# Altium schematic sheet PAGE3-USB_TO_UART.SchDoc(PAGE3-USB_TO_UART)
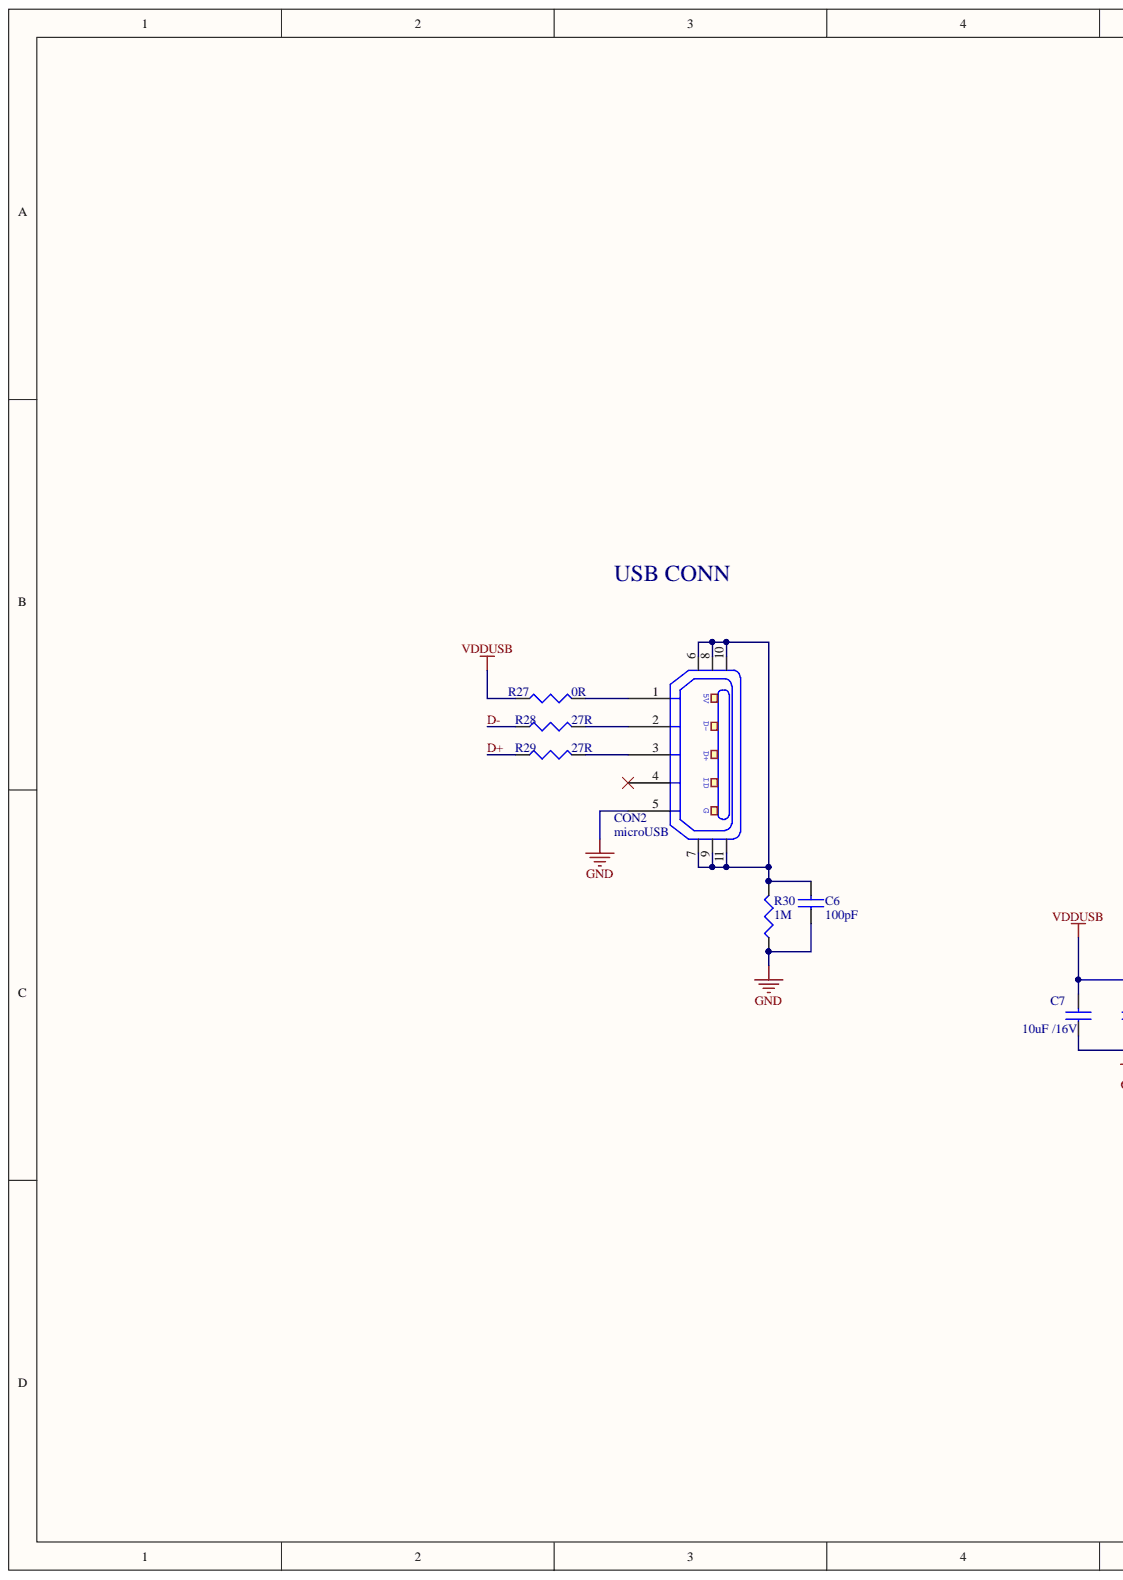
[diagram p0: PAGE3-USB_TO_UART.SchDoc, left]
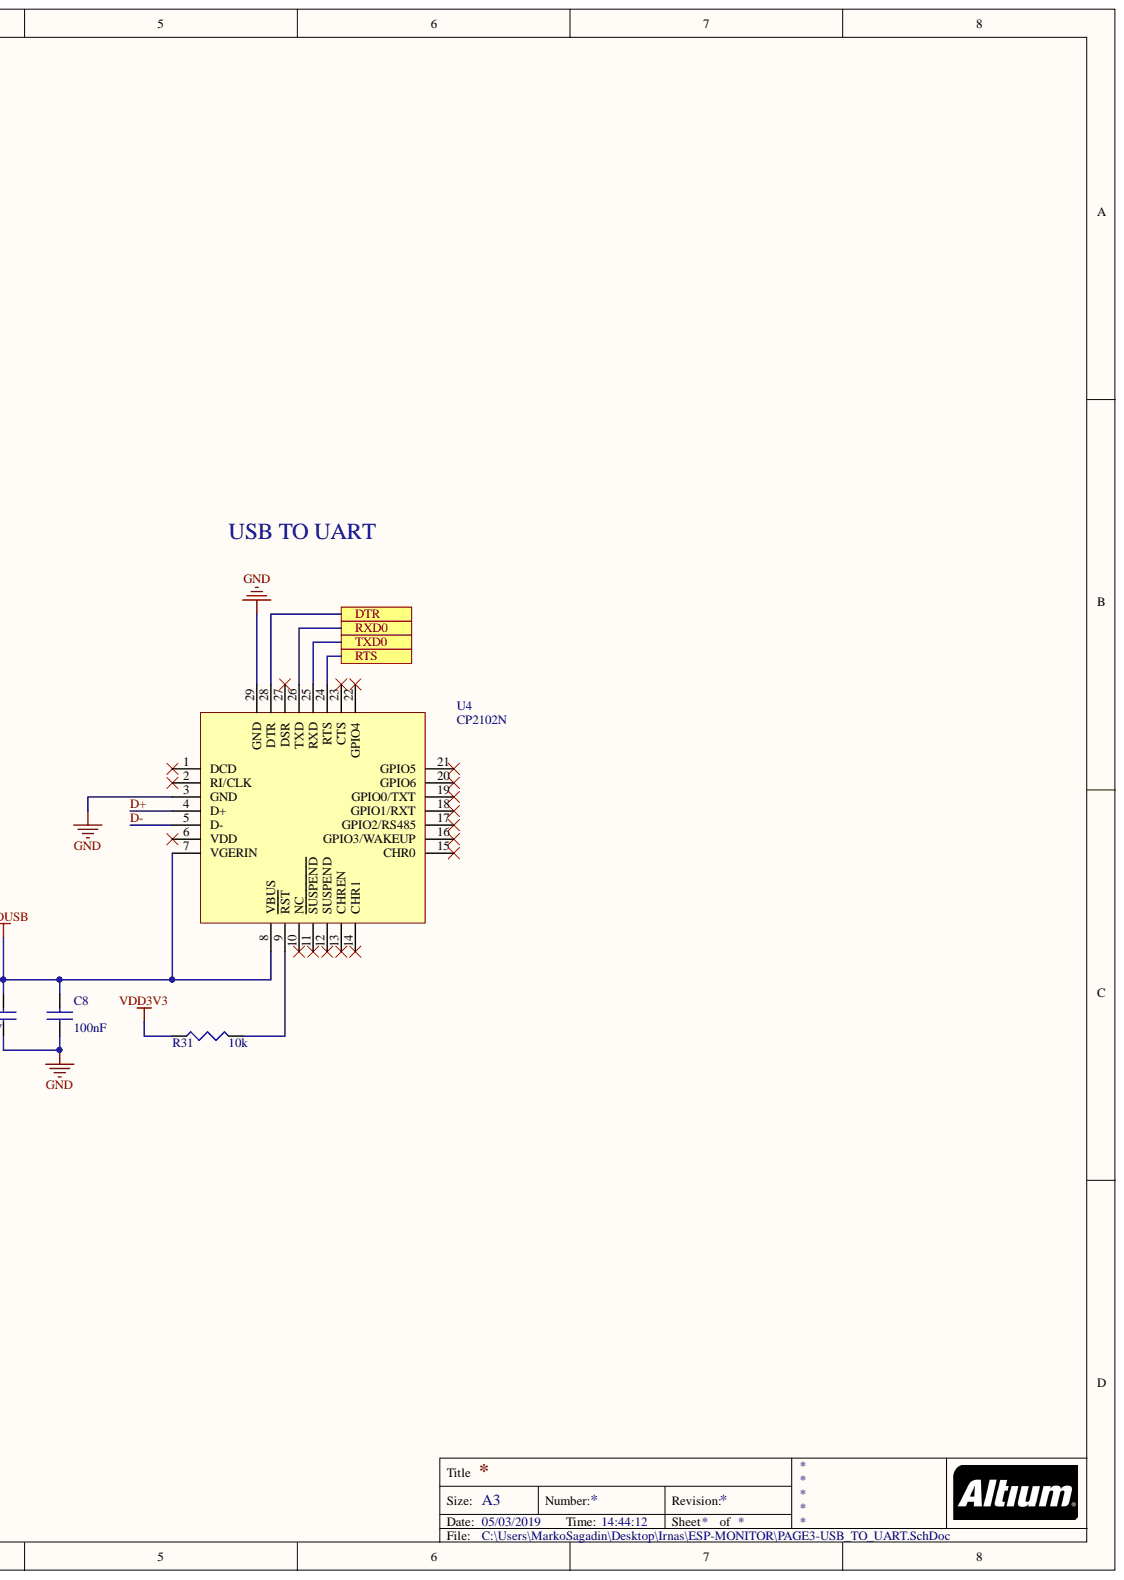
[diagram p1: PAGE3-USB_TO_UART.SchDoc, right]

## Parts
C6  100pF  [CAP0603]
C7  10uF /16V  [CAP0805]
C8  100nF  [CAP0603]
CON2  microUSB  [microUSB-CHINA]
R27  0R  [RES0603]
R28  27R  [RES0603]
R29  27R  [RES0603]
R30  1M  [RES0603]
R31  10k  [RES0603]
U4  CP2102N  [QFN50P500X500X80_HS-29N]

## Ports
DTR, RTS, RXD0, TXD0

## Nets
GND: C6.1 C7.1 C8.1 CON2.5 R30.1 U4.3(GND) U4.29(GND)
VDD3V3: R31.1
VDDUSB: C7.2 C8.2 R27.1 U4.7(VGERIN) U4.8(VBUS)
D+: R29.1 U4.4(D+)
D-: R28.1 U4.5(D-)
DTR: U4.28(DTR) ; port DTR
RTS: U4.24(RTS) ; port RTS
RXD0: U4.26(TXD) ; port RXD0
TXD0: U4.25(RXD) ; port TXD0
NetC6_2: C6.2 CON2.6 CON2.7 CON2.8 CON2.9 CON2.10 CON2.11 R30.2
NetCON2_1: CON2.1 R27.2
NetCON2_2: CON2.2 R28.2
NetCON2_3: CON2.3 R29.2
NetR31_2: R31.2 U4.9(RST)

Not connected: CON2 4; U4 1(DCD) 2(RI/CLK) 6(VDD) 10(NC) 11(SUSPEND) 12(SUSPEND) 13(CHREN) 14(CHR1) 15(CHR0) 16(GPIO3/WAKEUP) 17(GPIO2/RS485) 18(GPIO1/RXT) 19(GPIO0/TXT) 20(GPIO6) 21(GPIO5) 22(GPIO4) 23(CTS) 27(DSR)

## Library data of the parts
C6 = 100pF: MULTICOMP   MCSH18B101K500CT   SMD Multilayer Ceramic Capacitor, MCSH Series, 100 pF,  10%, X7R, 50 V, 0603 [1608 Metric]; Voltage Rating (DC): 50V; Voltage Rating: 50V; Tolerance: 10%; Supplier Part Number 1: 1856366; Supplier 1: Farnell; Stock 1: 9630; REACH SVHC: No SVHC; Pricing 1: 10=0.0858, 100=0.0386, 500=0.0266, 1000=0.0203, 2000=0.0176 (EUR); Packaging: Cut Tape; Min Operating Temperature: -55°C; Max Operating Temperature: 125°C; Manufacturer Part Number: MCSH18B101K500CT; Manufacturer: Multicomp; Library Name: Capacitors.IntLib; Dielectric: X7R; ComponentLink2URL: https://datasheet.ciiva.com/36855/1825494-36855530.pdf; ComponentLink2Description: https://datasheet.ciiva.com/36855/1825494-36855530.pdf; ComponentLink1URL: https://datasheet.ciiva.com/36858/1825494-36858405.pdf; ComponentLink1Description: https://datasheet.ciiva.com/36858/1825494-36858405.pdf; Component Kind: Standard; Case Code (Metric): 1608; Case Code (Imperial): 0603; Case/Package: 0603; Capacitance: 100pF
C7 = 10uF /16V: TDK - CGA4J1X5R1C106K125AC - CAP, MLCC, X5R, 10UF, 16V, 0805; Supplier Part Number 1: 2210948RL; Supplier 1: Farnell; Stock 1: 8974; RoHS: YES; Pricing 1: 5=0.41, 50=0.146, 250=0.131, 500=0.12, 1000=0.103 (GBP); Manufacturer Part Number: CGA4J1X5R1C106K125AC; Manufacturer: TDK; Library Name: Capacitors.IntLib; ComponentLink3URL: http://www.farnell.com/datasheets/1683658.pdf; ComponentLink3Description: http://www.farnell.com/datasheets/1683658.pdf; ComponentLink2URL: http://www.farnell.com/datasheets/1936565.pdf; ComponentLink2Description: http://www.farnell.com/datasheets/1936565.pdf; ComponentLink1URL: http://bcove.me/e1v54mtm; ComponentLink1Description: http://bcove.me/e1v54mtm; Component Kind: Standard
C8 = 100nF: MURATA - GRM188R71C104KA01J - CAP, MLCC, X7R, 0.1UF, 16V, 0603; Voltage Rating: 16; SVHC: No SVHC (20-Jun-2016; Product Range: GRM Series; Dielectric Characteristic: X7; Ceramic Capacitor Case: 0603 [1608 Metric]; Capacitance Tolerance: ± 10%; Capacitance: 0.1; Supplier Part Number 1: 2688519; Supplier 1: Farnell; Stock 1: 18297; RoHS: YES; Pricing 1: 10=0.0145, 100=0.0126, 500=0.0081, 2500=0.0059, 5000=0.0052, 10000=0.0046, 50000=0.0036, 100000=0.0035 (GBP); Packaging: Cut Tape; Operating Temperature Min: -55; Operating Temperature Max: 125; MSL: MSL 1 - Unlimited; Manufacturer Part Number: GRM188R71C104KA01J; Manufacturer: MURATA; Library Name: Capacitors.IntLib; ComponentLink1URL: http://www.farnell.com/datasheets/2189124.pdf; ComponentLink1Description: http://www.farnell.com/datasheets/2189124.pdf; Component Kind: Standard; Automotive Qualification Standard: -
CON2 = Micro USB CHINA 1: Library Name: USB_Connector_Lib_20150530.IntLib; Component Kind: Standard
R27 = 0R: BOURNS - CR0603-J/-000ELF - RES, THICK FILM, 0R, 5%, 0.1W, 0603; Voltage Rating: 50; SVHC: No SVHC (17-Dec-2015); Resistor Mounting: Surface Mount Device<!--FANDB--><div class="features-and-benefits-container"><div class="features-and-benefits-description"><span class="features-benefits-value">The Bourns CR series are standard thick film chip resistors. These resistors provide flexibility of performance, space saving and low cost. The Resistance range includes E96 and E24 decade values from 1ohm to 10Mohm.</span></div><div class="features-and-benefits-list"><ul class="features-and-benefits-list-list"><li class="features-and-benefits-list-item">0201, 0402, 0603, 0805, 1206, 1210, 2010, 2512 standard case sizes available</li><li class="features-and-benefits-list-item">±1% and ±5% tolerance</li><li class="features-and-benefits-list-item">±100ppm/°C, ±200ppm/°C and ±250ppm/°C TCR values available</li><li class="features-and-benefits-list-item">Power rating selectable for 50mW, 63mW, 0.1W, 0.125W, 0.25W, 0.5W and 1W based on ordering</li><li class="features-and-benefits-list-item">Jumpers are available for all size</li><li class="features-and-benefits-list-item">3 layer termination process with nickel barrier prevents leaching & provides excellent solderability</li><li class="features-and-benefits-list-item">Suitable for all type of soldering processes</li><li class="features-and-benefits-list-item">Tight dimensional tolerances</li><li class="features-and-benefits-list-item">Maximum working voltage depends on case size</li><li class="features-and-benefits-list-item">Operating temperature of -55°C to +125°C(CR0201) and -55°C to +155; Resistor Case Style: 0603 [1608 Metric]; Resistance: 0; Product Range: CR Series; Power Rating: 100; Temperature Coefficient: -; Supplier Part Number 1: 2008343; Supplier 1: Farnell; Stock 1: 58200; RoHS: YES; Resistor Element Material: Thick Film; Resistance Tolerance: ± 5%; Pricing 1: 10=0.0146, 250=0.0097, 1000=0.0073, 5000=0.0049, 12500=0.0042 (GBP); Packaging: Cut Tape; MSL: MSL 1 - Unlimited; Manufacturer Part Number: CR0603-J/-000ELF; Manufacturer: BOURNS; Library Name: Resistors.IntLib; ComponentLink2URL: http://www.farnell.com/datasheets/2178762.pdf; ComponentLink2Description: http://www.farnell.com/datasheets/2178762.pdf; ComponentLink1URL: http://www.bourns.com/engineering/CHPREZTR/cr0603.stp; ComponentLink1Description: http://www.bourns.com/engineering/CHPREZTR/cr0603.stp; Component Kind: Standard; Automotive Qualification Standard: -
R28 R29 = 27R: MULTICOMP         MCWR06X27R0FTL            Surface Mount Chip Resistor, Thick Film, MCWR Series, 27 ohm, 100 mW,  1%, 50 V; Voltage Rating (DC): 50V; Temperature Coefficient: 100ppm/°C; Power Rating: 100mW; Voltage Rating: 50V; Tolerance: 1%; Supplier Part Number 1: 2447316; Supplier 1: Farnell; Stock 1: 0; Resistance: 27R; REACH SVHC: Unknown; Packaging: Cut Tape; Mount: Surface Mount; Manufacturer Part Number: MCWR06X27R0FTL; Manufacturer: Multicomp; Library Name: Resistors.IntLib; Composition: Thick Film; ComponentLink1URL: https://datasheet.ciiva.com/46436/1849611-46436376.pdf; ComponentLink1Description: https://datasheet.ciiva.com/46436/1849611-46436376.pdf; Component Kind: Standard; Case Code (Metric): 1608; Case Code (Imperial): 0603; Case/Package: 0603
R30 = 1M: MULTICOMP - MC0063W060311M - RES, THICK FILM, 1M, 1%, 0.063W, 0603; Voltage Rating V DC: 50V<!--FANDB--><div class="features-and-benefits-container"><div class="features-and-benefits-description"><span class="features-benefits-value">The Multicomp MC series current sense resistors are thick film chip resistors. The resistance range includes E96 and E24 decade values from 1ohm to 10Mohm.</span></div><div class="features-and-benefits-list"><ul class="features-and-benefits-list-list"><li class="features-and-benefits-list-item">EIA 0402, 0603, 0805, 1206 chip sizes available</li><li class="features-and-benefits-list-item">Small size and low weight</li><li class="features-and-benefits-list-item">Suitable for both wave and reflow soldering</li><li class="features-and-benefits-list-item">Reduction of assembly costs</li><li class="features-and-benefits-list-item">Maximum working voltage of 50V(0402, 0603), 150V(0805), 200V(1206)</li><li class="features-and-benefits-list-item">Available in ±1%, ±2% and ±5% tolerance</li><li class="features-and-benefits-list-item">Power rating of 62.5mW, 100mW and 125mW</li><li class="features-and-benefits-list-item">±400ppm/°C(1ohm to 10ohm), ±200ppm/°C(11ohm to 100ohm), ±100; Voltage Rating: 50; Temperature Coefficient: ± 100; Temperature Coeff. -: 100; SVHC: No SVHC (17-Dec-2015); Resistor Mounting: Surface Mount Device; Resistor Case Style: 0603 [1608 Metric]; Resistance: 1; Reel Quantity: 5000; Product Range: MC Series; Power Rating: 63; No. of Pins: 2; External Width: 0.8; External Length / Height: 1.6; External Depth: 0.45; Tolerance -: 1%; Tolerance +: 1%; Temperature Coeff. +: 100; Tape Width: 8; Supplier Part Number 1: 9330410; Supplier 1: Farnell; Stock 1: 333415; RoHS: Y-EX; Resistor Element Material: Thick Film; Resistance Tolerance: ± 1%; Pricing 1: 10=0.0011, 100=0.001, 500=0.0009, 1000=0.0008, 2500=0.0007 (GBP); Packaging: Cut Tape; Operating Temperature Range: -55°C to +155; Operating Temperature Min: -55; Operating Temperature Max: 155; Manufacturer Part Number: MC0063W060311M; Manufacturer: MULTICOMP; Library Name: Resistors.IntLib; ComponentLink2URL: http://www.farnell.com/cad/1558928.zip; ComponentLink2Description: http://www.farnell.com/cad/1558928.zip; ComponentLink1URL: http://www.farnell.com/datasheets/1788326.pdf; ComponentLink1Description: http://www.farnell.com/datasheets/1788326.pdf; Component Kind: Standard; Automotive Qualification Standard: -
R31 = 10k: MULTICOMP - MCMR06X103 JTL - RES, CERAMIC, 10K, 5%, 0.1W, 0603; Voltage Rating: 75; Temperature Coefficient: ± 100; Resistor Case Style: 0603 [1608 Metric]; Resistance: 10; Product Range: MCMR Series; Power Rating: 100; Automotive Qualification Standard: -<!--FANDB--><div class="features-and-benefits-container"><div class="features-and-benefits-description"><span class="features-benefits-value">The Multicomp MCMR series resistors are automotive grade, anti sulphured thick film chip resistor. The resistance range includes E24 and E96 decade values from 1ohm to 10Mohm. These resistors are constructed on top of a high grade ceramic body.</span></div><div class="features-and-benefits-list"><ul class="features-and-benefits-list-list"><li class="features-and-benefits-list-item">EIA 0402, 0603, 0805, 1206 chip sizes available</li><li class="features-and-benefits-list-item">High reliability and stability</li><li class="features-and-benefits-list-item">Automotive grade AEC-Q200 compliant</li><li class="features-and-benefits-list-item">Complaint with (IEC 60068-1) 55/155/56 climatic category</li><li class="features-and-benefits-list-item">Maximum operation voltage of 50V, 75V, 150V and 200V</li><li class="features-and-benefits-list-item">Power rating of 62.5mW, 100mW, 125mW and 250mW</li><li class="features-and-benefits-list-item">Available in ±1% and ±5% tolerance</li><li class="features-and-benefits-list-item">Temperature coefficient (TCR) of +200ppm/°C, +100ppm/°C and -200ppm/°C to +400ppm/°C</li><li class="features-and-benefits-list-item">Operating temperature range -55°C to 155; Supplier Part Number 1: 2073356; Supplier 1: Farnell; Stock 1: 19791; RoHS: Y-EX; Resistor Element Material: Ceramic; Resistance Tolerance: ± 5%; Pricing 1: 10=0.0087, 100=0.0074, 500=0.0061, 1000=0.0048, 2500=0.0032, 5000=0.0024, 25000=0.0016, 50000=0.0013 (GBP); Packaging: Cut Tape; Manufacturer Part Number: MCMR06X103 JTL; Manufacturer: MULTICOMP; Library Name: Resistors.IntLib; ComponentLink1URL: http://www.farnell.com/datasheets/1716707.pdf; ComponentLink1Description: http://www.farnell.com/datasheets/1716707.pdf; Component Kind: Standard
U4 = CP2102N-A01-GQFN28: SILICON LABS         CP2102N-A01-GQFN28             Interface Bridges, USB to UART, 3 V, 3.6 V, QFN, 28 Pins, -40 C                          New; Min Supply Voltage: 3V; Max Supply Voltage: 3.6V; Supplier Part Number 1: 2577279; Supplier 1: Farnell; Stock 1: 729; REACH SVHC: Unknown; Pricing 1: 1=1.31, 10=1.2, 25=1.11, 50=1.09, 100=1.07, 250=1.05, 500=1.03, 1000=1.01 (GBP); Number of Pins: 28; Min Operating Temperature: -40°C; Max Operating Temperature: 85°C; Manufacturer Part Number: CP2102N-A01-GQFN28; Manufacturer: Silicon Labs; ComponentLink2URL: https://download.mikroe.com/documents/add-on-boards/click/usb-uart-3/usb-3-uart-click-schematic-v100.pdf; ComponentLink2Description: Reference design; ComponentLink1URL: https://www.silabs.com/documents/public/data-sheets/cp2102n-datasheet.pdf; ComponentLink1Description: Datasheet; Component Kind: Standard; Case/Package: QFN; Library Name: gliax_ecg_simple.SchLib
